# Altium schematic sheet Connectors pt1.SchDoc("Connectors pt1")
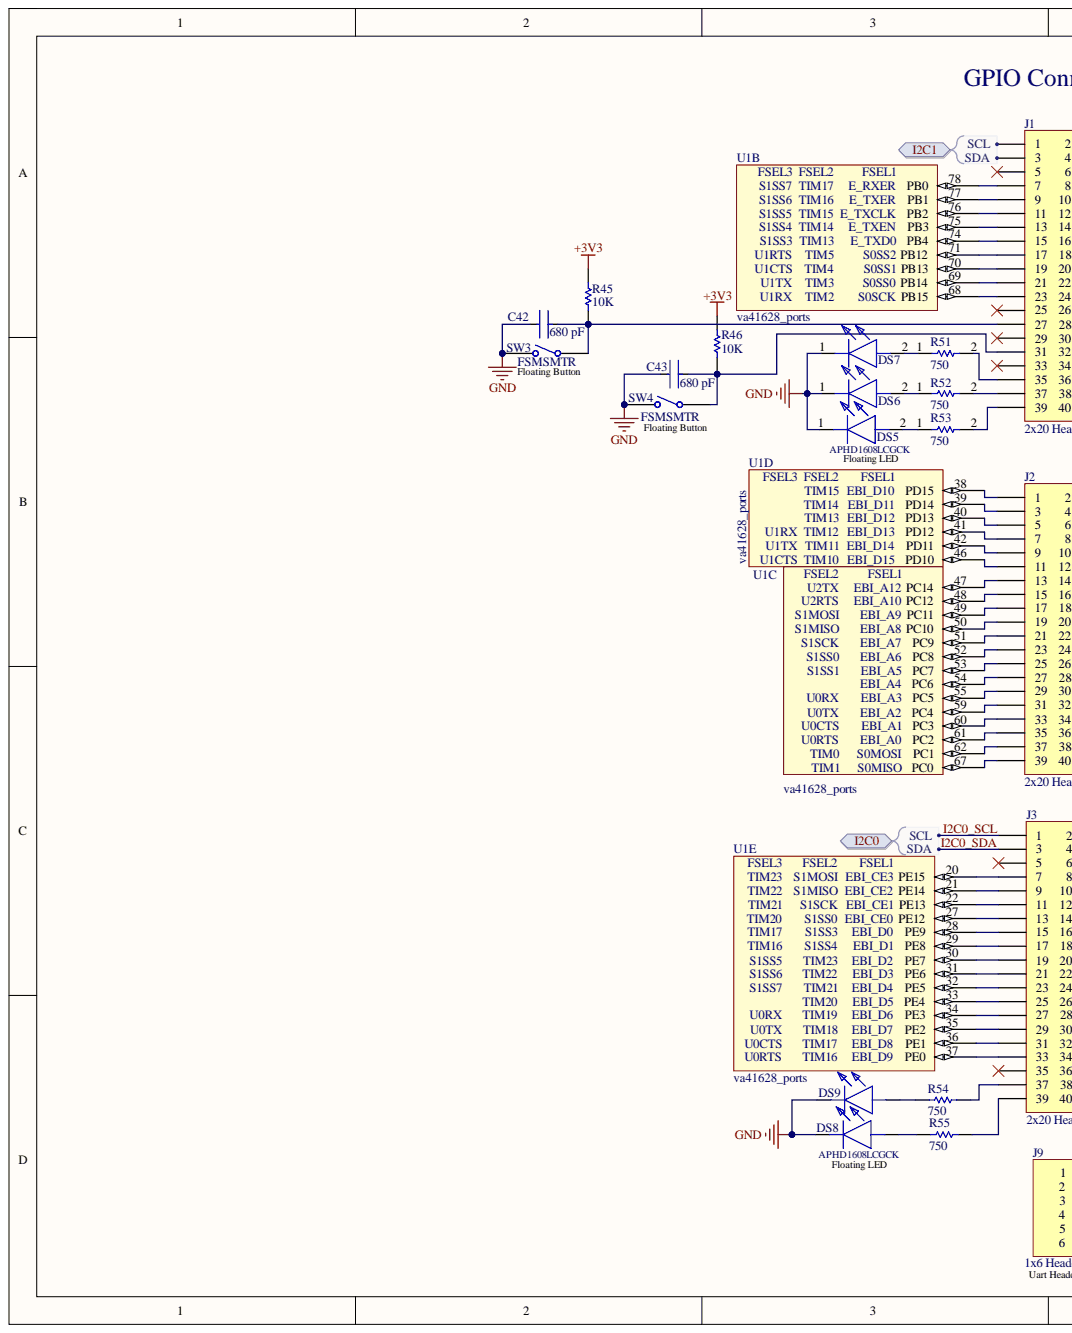
[diagram p0: Connectors pt1.SchDoc, left]
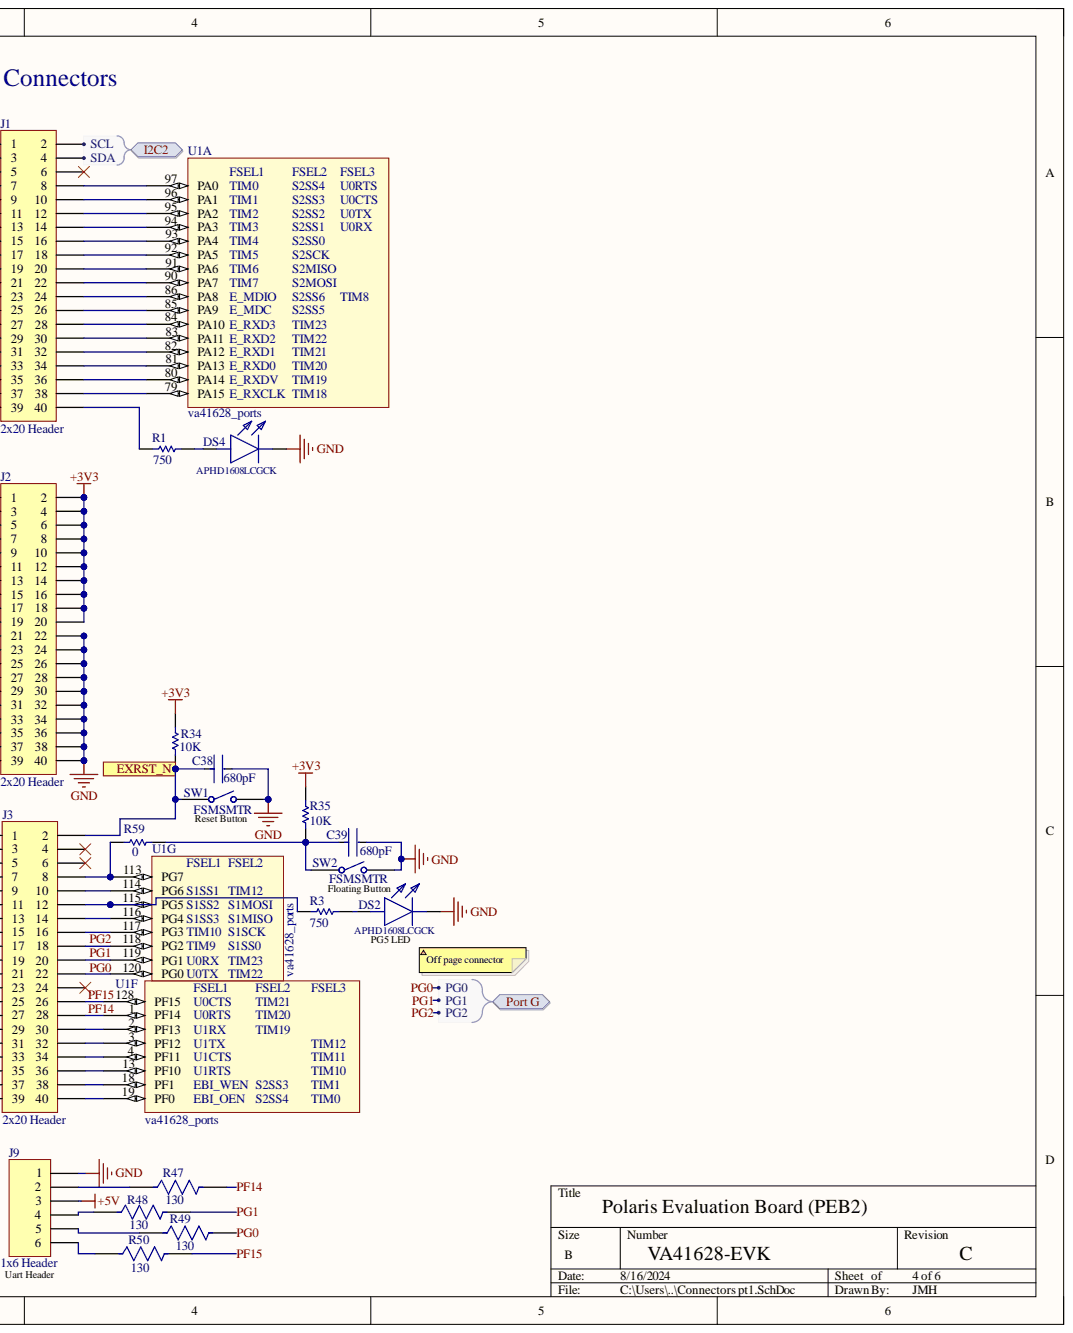
[diagram p1: Connectors pt1.SchDoc, right]

## Parts
C38  680pF  [C0603C681K5GACTU]
C39  680pF  [C0603C681K5GACTU]
C42  680pF  [C0603C681K5GACTU]
C43  680pF  [C0603C681K5GACTU]
DS2  APHD1608LCGCK  [APHD1608LCGCK]
DS4  APHD1608LCGCK  [APHD1608LCGCK]
DS5  APHD1608LCGCK  [APHD1608LCGCK]
DS6  (no value)  [APHD1608LCGCK]
DS7  (no value)  [APHD1608LCGCK]
DS8  APHD1608LCGCK  [APHD1608LCGCK]
DS9  (no value)  [APHD1608LCGCK]
J1  2x20 Header  [61304011121]
J2  2x20 Header  [61304011121]
J3  2x20 Header  [61304011121]
J9  1x6 Header  [61300611121]
R1  750  [CRCW0603750RJNEA]
R3  750  [CRCW0603750RJNEA]
R34  10k  [CRCW060310K0FKEAC]
R35  10k  [CRCW060310K0FKEAC]
R45  10k  [CRCW060310K0FKEAC]
R46  10k  [CRCW060310K0FKEAC]
R47  130  [CRCW0603130RFKEAC]
R48  130  [CRCW0603130RFKEAC]
R49  130  [CRCW0603130RFKEAC]
R50  130  [CRCW0603130RFKEAC]
R51  750  [CRCW0603750RJNEA]
R52  750  [CRCW0603750RJNEA]
R53  750  [CRCW0603750RJNEA]
R54  750  [CRCW0603750RJNEA]
R55  750  [CRCW0603750RJNEA]
R59  0  [0OHM]
SW1  FSMSMTR  [FSMSMTR]
SW2  FSMSMTR  [FSMSMTR]
SW3  FSMSMTR  [FSMSMTR]
SW4  FSMSMTR  [FSMSMTR]
U1  va41628_ports  [TCQFP-128-0.40-36-C]  (parts A,B,C,D,E,F,G)

## Ports
EXRST_N, I2C0, I2C0.SCL, I2C0.SDA, I2C1, I2C1.SCL, I2C1.SDA, I2C2, I2C2.SCL, I2C2.SDA, Port G, Port G.PG0, Port G.PG1, Port G.PG2

## Nets
+3V3: J2.2 J2.4 J2.6 J2.8 J2.10 J2.12 J2.14 J2.16 J2.18 J2.20 R34.1 R35.1 R45.1 R46.1
+5V: J9.3
GND: C38.2 C39.2 C42.2 C43.2 DS2.1 DS4.1 DS5.1 DS6.1 DS7.1 DS8.1 DS9.1 J2.22 J2.24 J2.26 J2.28 J2.30 J2.32 J2.34 J2.36 J2.38 J2.40 J9.1 SW1.2 SW2.2 SW3.2 SW4.2
EXRST_N: C38.1 J3.2 R34.2 SW1.1 ; port EXRST_N
I2C0_SCL: J3.1 ; port I2C0
I2C0_SDA: J3.3 ; port I2C0
PF14: J3.28 R47.2 U1.1(PF14)
PF15: J3.26 R50.2 U1.128(PF15)
PG0: J3.22 R49.2 U1.120(PG0_U0TX) ; port Port G
PG1: J3.20 R48.2 U1.119(PG1_U0RX) ; port Port G
PG2: J3.18 U1.118(PG2_TIM9) ; port Port G
NetC39_1: C39.1 R35.2 R59.2 SW2.1
NetC42_1: C42.1 J1.27 R45.2 SW3.1
NetC43_1: C43.1 J1.31 R46.2 SW4.1
NetDS2_2: DS2.2 R3.2
NetDS4_2: DS4.2 R1.1
NetDS5_2: DS5.2 R53.1
NetDS6_2: DS6.2 R52.1
NetDS7_2: DS7.2 R51.1
NetDS8_2: DS8.2 R55.1
NetDS9_2: DS9.2 R54.1
NetJ1_1: J1.1 ; port I2C1
NetJ1_2: J1.2 ; port I2C2
NetJ1_3: J1.3 ; port I2C1
NetJ1_4: J1.4 ; port I2C2
NetJ1_7: J1.7 U1.78(PB0)
NetJ1_8: J1.8 U1.97(PA0)
NetJ1_9: J1.9 U1.77(PB1)
NetJ1_10: J1.10 U1.96(PA1)
NetJ1_11: J1.11 U1.76(PB2)
NetJ1_12: J1.12 U1.95(PA2)
NetJ1_13: J1.13 U1.75(PB3)
NetJ1_14: J1.14 U1.94(PA3)
NetJ1_15: J1.15 U1.74(PB4)
NetJ1_16: J1.16 U1.93(PA4)
NetJ1_17: J1.17 U1.71(S0SS2_PB12)
NetJ1_18: J1.18 U1.92(PA5)
NetJ1_19: J1.19 U1.70(S0SS1_PB13)
NetJ1_20: J1.20 U1.91(PA6)
NetJ1_21: J1.21 U1.69(S0SS0_PB14)
NetJ1_22: J1.22 U1.90(PA7)
NetJ1_23: J1.23 U1.68(PB15)
NetJ1_24: J1.24 U1.86(PA8)
NetJ1_26: J1.26 U1.85(PA9)
NetJ1_28: J1.28 U1.84(PA10_E_RXD3)
NetJ1_30: J1.30 U1.83(PA11)
NetJ1_32: J1.32 U1.82(PA12_E_RXD1)
NetJ1_34: J1.34 U1.81(PA13_E_RXD0)
NetJ1_35: J1.35 R51.2
NetJ1_36: J1.36 U1.80(PA14_E_RXDV)
NetJ1_37: J1.37 R52.2
NetJ1_38: J1.38 U1.79(PA15_E_RXCLK)
NetJ1_39: J1.39 R53.2
NetJ1_40: J1.40 R1.2
NetJ2_1: J2.1 U1.38(PD15)
NetJ2_3: J2.3 U1.39(PD14)
NetJ2_5: J2.5 U1.40(PD13)
NetJ2_7: J2.7 U1.41(PD12)
NetJ2_9: J2.9 U1.42(PD11)
NetJ2_11: J2.11 U1.46(PD10)
NetJ2_13: J2.13 U1.47(PC14)
NetJ2_15: J2.15 U1.48(PC12)
NetJ2_17: J2.17 U1.49(PC11)
NetJ2_19: J2.19 U1.50(EBI_A8_PC10)
NetJ2_21: J2.21 U1.51(PC9)
NetJ2_23: J2.23 U1.52(PC8)
NetJ2_25: J2.25 U1.53(PC7)
NetJ2_27: J2.27 U1.54(PC6)
NetJ2_29: J2.29 U1.55(PC5)
NetJ2_31: J2.31 U1.59(PC4)
NetJ2_33: J2.33 U1.60(PC3)
NetJ2_35: J2.35 U1.61(PC2)
NetJ2_37: J2.37 U1.62(PC1)
NetJ2_39: J2.39 U1.67(PC0)
NetJ3_7: J3.7 U1.20(PE15)
NetJ3_8: J3.8 R59.1 U1.113(PG7)
NetJ3_9: J3.9 U1.21(PE14)
NetJ3_10: J3.10 U1.114(PG6_S1SS1)
NetJ3_11: J3.11 U1.22(PE13)
NetJ3_12: J3.12 R3.1 U1.115(PG5_S1SS2)
NetJ3_13: J3.13 U1.27(PE12)
NetJ3_14: J3.14 U1.116(PG4_S1SS3)
NetJ3_15: J3.15 U1.28(PE9)
NetJ3_16: J3.16 U1.117(PG3_TIM10)
NetJ3_17: J3.17 U1.29(PE8)
NetJ3_19: J3.19 U1.30(PE7)
NetJ3_21: J3.21 U1.31(PE6)
NetJ3_23: J3.23 U1.32(PE5)
NetJ3_25: J3.25 U1.33(PE4)
NetJ3_27: J3.27 U1.34(PE3)
NetJ3_29: J3.29 U1.35(PE2)
NetJ3_30: J3.30 U1.2(PF13)
NetJ3_31: J3.31 U1.36(PE1)
NetJ3_32: J3.32 U1.3(PF12)
NetJ3_33: J3.33 U1.37(PE0)
NetJ3_34: J3.34 U1.4(PF11)
NetJ3_36: J3.36 U1.13(PF10)
NetJ3_37: J3.37 R54.2
NetJ3_38: J3.38 U1.18(PF1)
NetJ3_39: J3.39 R55.2
NetJ3_40: J3.40 U1.19(PF0)
NetJ9_2: J9.2 R47.1
NetJ9_4: J9.4 R48.1
NetJ9_5: J9.5 R49.1
NetJ9_6: J9.6 R50.1

Not connected: J1 5 6 25 29 33; J3 4 5 6 24 35

## Library data of the parts
C38 C39 C42 C43 = CMP-2006-03561-2: CAP CER 680PF 50V NP0 0603; Manufacturer: KEMET; Automotive Grade: Grade 1; Material: Ceramic; Temperature Characteristic: C0G; Temperature Coefficient: 30ppm/°C; Tolerance: 0.1; Automotive: Yes; Capacitance: 680 pF; Category: Cap; Component Kind: Standard; ComponentLink2Description: Datasheet; ComponentLink2URL: https://api.kemet.com/component-edge/download/datasheet/C0603C100J5GACAUTO.pdf; Device Class L1: Passive Components; Device Class L2: Capacitors; Device Class L3: Ceramic Capacitors; Digikey Description: CAP CER 680PF 50V NP0 0603; Height: 0.87mm; Lead Free: Yes; Library Name: Altium Content Vault; Manufacturer Part Number: C0603C681K5GACTU; Mouser Description: Multilayer Ceramic Capacitors MLCC - SMD/SMT 50volts 680pF C0G 10%; Octopart: https://octopart.com/c0603c681k5gactu-kemet-12577598; Package: C0603C-CF; RoHS: TRUE; Temperature: -55°C to +125°C; Temperature Range High: +125°C; Temperature Range Low: -55°C; Voltage Rating: 50 V
  C38 C39: Value: 680pF
  C42 C43: Value: 680 pF
DS2 DS4-DS9 = APHD1608LCGCK: LED; Manufacturer_Name: Kingbright; Arrow Part Number: APHD1608LCGCK; Arrow Price/Stock: https://www.arrow.com/en/products/aphd1608lcgck/kingbright?region=nac; Component Kind: Standard; Datasheet Link: http://www.kingbrightusa.com/images/catalog/SPEC/APHD1608LCGCK.pdf; Height: 0.8mm; Library Name: SamacSys.SchLib; Manufacturer_Part_Number: APHD1608LCGCK; Mouser Part Number: 604-APHD1608LCGCK; Mouser Price/Stock: https://www.mouser.co.uk/ProductDetail/Kingbright/APHD1608LCGCK?qs=AQlKX63v8RvqPbV1LDSVuw%3D%3D
J1-J3 = Header 20X2: Header, 20-Pin, Dual row; Component Kind: Standard; LatestRevisionDate: 17-Jul-2002; LatestRevisionNote: Re-released for DXP Platform.; Library Name: Miscellaneous Connectors.IntLib; Signal Integrity: Connector; Publisher: Altium Limited
J9 = Header 6: Header, 6-Pin; Component Kind: Standard; LatestRevisionDate: 17-Jul-2002; LatestRevisionNote: Re-released for DXP Platform.; Library Name: Miscellaneous Connectors.IntLib; Signal Integrity: Connector; Publisher: Altium Limited
R1 R3 R51-R55 = CMP-2000-03568-1: Manufacturer: Vishay Dale; Part Number: CRCW0603750RFKEA; Component Kind: Standard; Library Name: Altium Content Vault; Res: 750
R34 R35 R45 R46 = CMP-1666-00005-4: Chip Resistor, 10 KOhm, +/- 1%, 100 mW, -55 to 155 degC, 0603 (1608 Metric), RoHS, Tape and Reel; Manufacturer: Vishay Semiconductor; Voltage Rating: 75 V; Component Kind: Standard; ComponentLink1Description: Manufacturer URL; ComponentLink1URL: http://www.vishay.com/; ComponentLink2Description: Datasheet; ComponentLink2URL: https://datasheet.ciiva.com/11809/0900766b80d98afc-11809037.pdf; DatasheetVersion: 06/2012; Height: 0.45 mm; Length: 1.55 mm; Library Name: Altium Content Vault; Max Operating Temperature: 155 degC; Min Operating Temperature: -55 degC; Mounting Technology: Surface Mount; Package Quantity: 5000; PackageDescription: 2-Pin Surface Mount Device, Body 1.575 x 0.85 mm; PackageReference: 0603; Packaging: Tape and Reel; Parameter 1: 10K; REACH SVHC: Unknown; RoHSCompliant: true
R47-R50 = CMP-2000-03889-2: RES Thick Film, 130Ω, 1%, 0.1W, 100ppm/°C, 0603; Max Power Dissipation: 100mW; Voltage Rating: 75V; Case Code (Imperial): 0603; Case Code (Metric): 1608; Case/Package: 0603; Component Kind: Standard; Composition: Thick Film; Contact Plating: Tin; Depth: 0.85mm; Features: Automotive AEC-Q200; Height: 0.45mm; Lead Free: Lead Free; Length: 1.55mm; Library Name: Altium Content Vault; Max Operating Temperature: 155°C; Military Standard: Not; Min Operating Temperature: -55°C; Number of Pins: 2; Number of Terminations: 2; Package Quantity: 10; Packaging: Tape and Reel; Power Rating: 100mW; Radiation Hardening: No; Resistance: 130; Temperature Coefficient: 100ppm/°C; Termination: SMD/SMT; Tolerance: 1%; Voltage Rating (DC): 75V; Weight: 7.1E-05oz; Width: 0.85mm; Working Voltage: 75V
R59 = CMP-2000-03816-5: Chip Resistor, 0 Ohm, Jumper, 100 mW, -55 to 155 degC, 0603 (1608 Metric), RoHS, Tape and Reel; Manufacturer: Vishay Semiconductor; Voltage Rating: 75 V; Component Kind: Standard; ComponentLink1Description: Manufacturer URL; ComponentLink1URL: http://www.vishay.com/; ComponentLink2Description: Datasheet; ComponentLink2URL: http://www.vishay.com/docs/20035/dcrcwe3.pdf; DatasheetVersion: 06/2012; Height: 0.45 mm; Length: 1.55 mm; Library Name: Altium Content Vault; Max Operating Temperature: 155 degC; Min Operating Temperature: -55 degC; Mount: Surface Mount; Mounting Technology: Surface Mount; Package Quantity: 5000; PackageDescription: 2-Pin Surface Mount Device, Body 1.575 x 0.85 mm; PackageReference: 0603; Packaging: Tape and Reel; Parameter 1: 0; Pins: 2; REACH SVHC: Unknown; RoHSCompliant: true
SW1-SW4 = CMP-2000-07501-1: FSMSM Push Button Switch, 50 mA, -35 to 85 degC, 2-Pin SMD, RoHS, Tape and Reel; Manufacturer: TE Connectivity; Actuator Length: 1.07mm; Actuator Material: Polyamide; Case\/Package: SMD/SMT; Contact Current Rating: 50mA; Contact Resistance: 100mOhm; Electrical Life: 20000Cycles; Illumination Type: Illuminated; Max Voltage Rating (DC): 12V; Operating Force: 180gf; Switch Travel: 0.25mm; Throw Configuration: SPST; Voltage Rating (DC): 24V; Component Kind: Standard; Contact Material: Brass; Contact Plating: Silver; Current Rating: 50mA; Datasheet URL: http://www.te.com/commerce/DocumentDelivery/DDEController?Action=showdoc&DocId=Customer+Drawing%7F1437566-3%7FC2%7Fpdf%7FEnglish%7FENG_CD_1437566-3_C2_1437566_3_1_c.dwg.pdf%7F1437566-4; Datasheet Version: Rev. C2, 08/2014; ELV: Compliant; Lead Free: LeadFree; Library Name: Altium Content Vault; Manufacturer URL: http://www.te.com/; Max Operating Temperature: 85degC; Mechanical Life: 20000Cycles; Min Operating Temperature: -35degC; Mount: SurfaceMount; Mounting Technology: SurfaceMount; Orientation: Straight; Package Description: 2-Pin Surface Mount Device, Body 6 x 3.5 mm, Pitch 9.19 mm; Package Quantity: 1800; Package Reference: FSMSMTR; Packaging: TapeandReel; REACH SVHC: Unknown; RoHSCompliant: true; Termination: GullWing
U1 = va41628_ports: Polaris Lite 128 pin; Component Kind: Standard; Library Name: va416xx.SchLib
